# Altium schematic sheet PHE_Keypad_R02.SchDoc
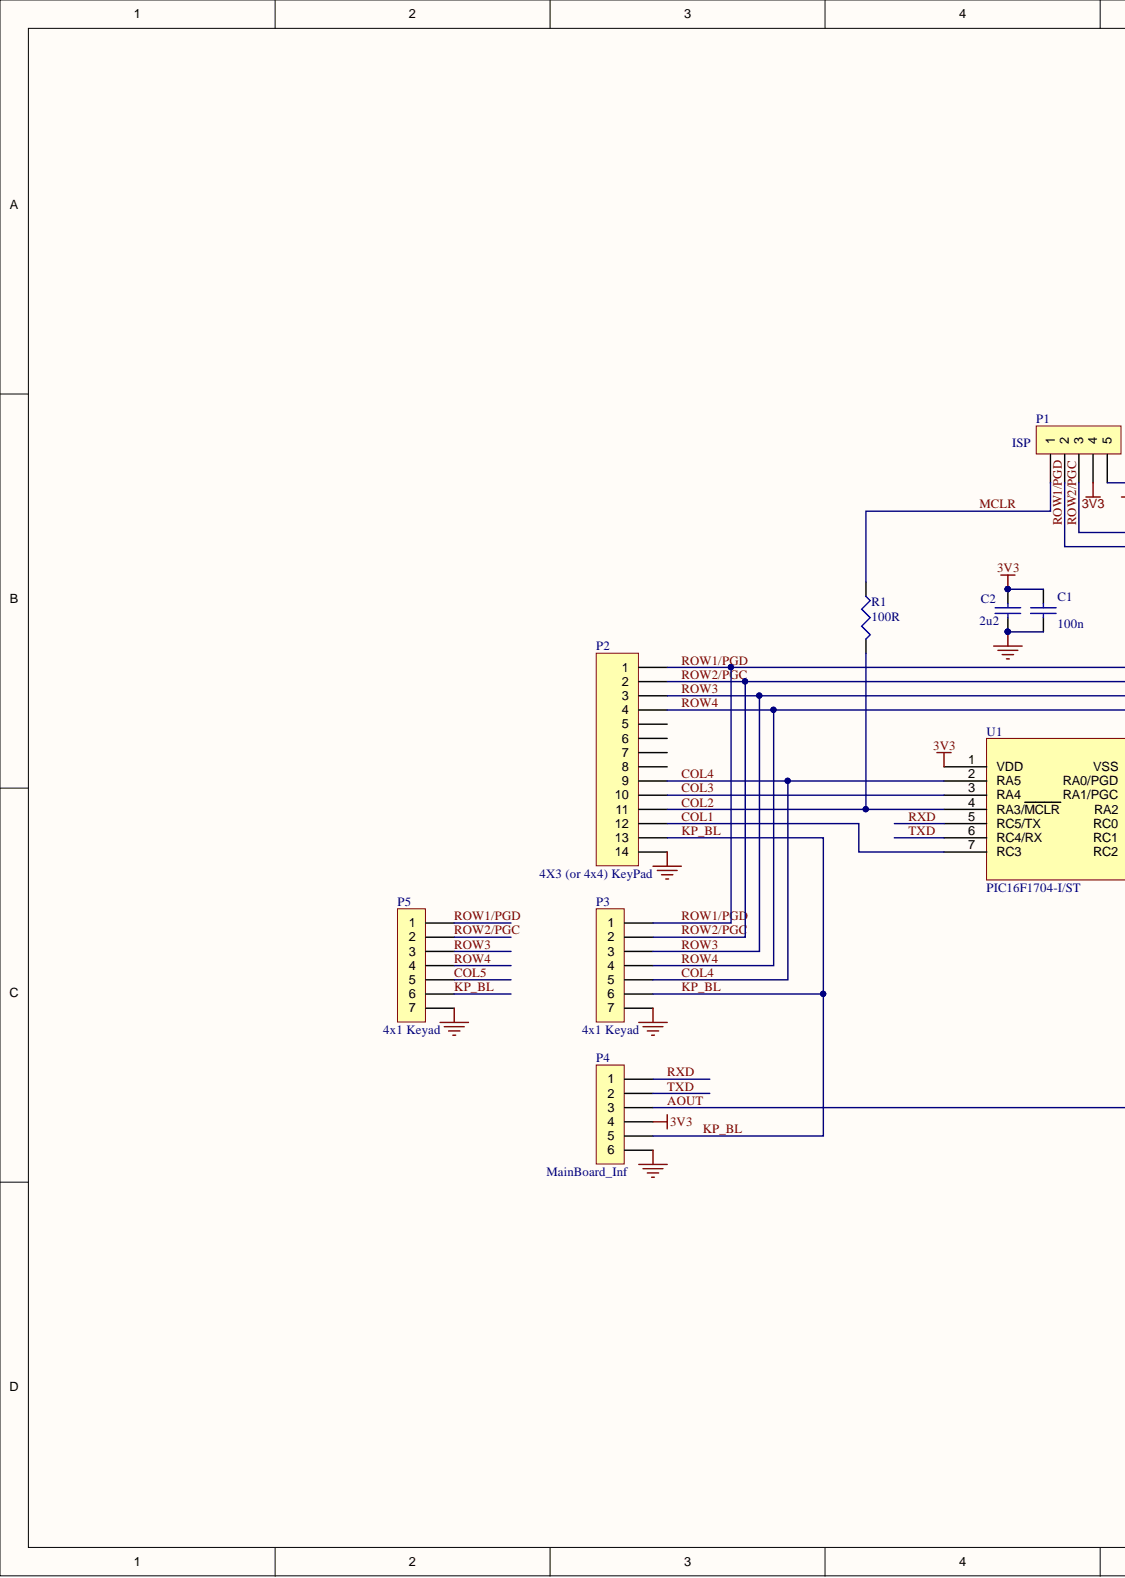
[diagram p0: PHE_Keypad_R02.SchDoc, left]
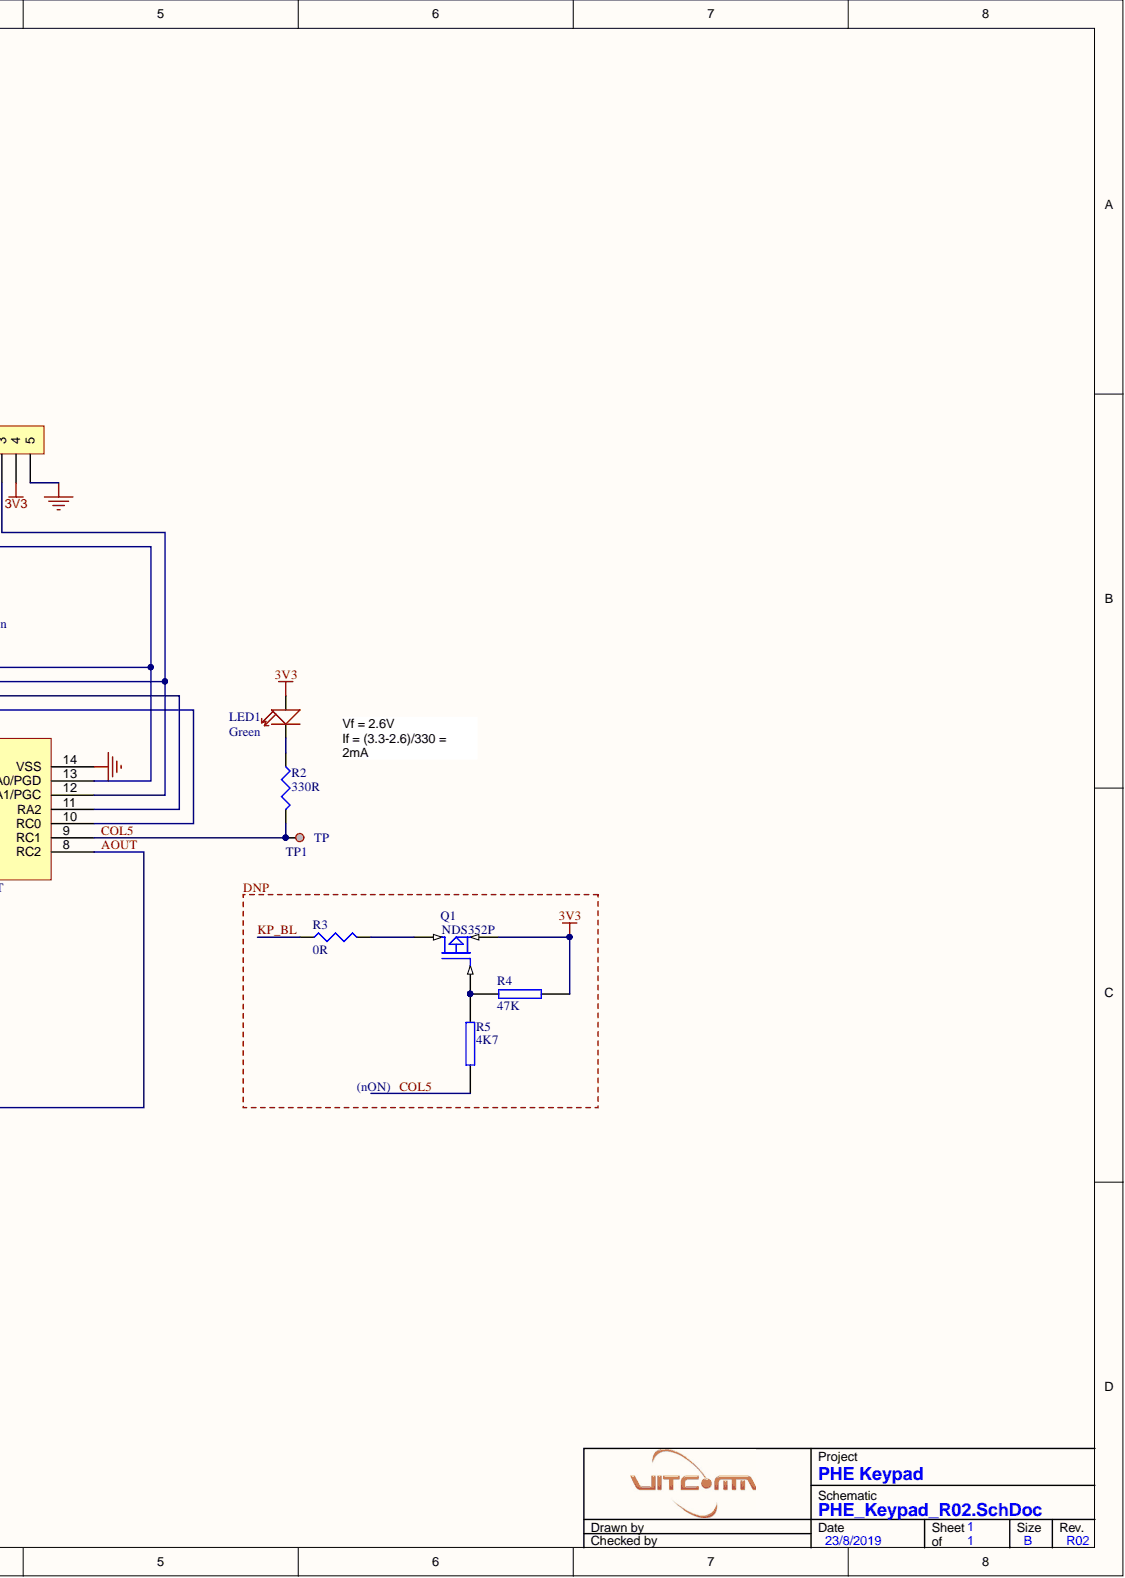
[diagram p1: PHE_Keypad_R02.SchDoc, right]

## Parts
C1  100n  [0603N]
C2  2u2  [0805N]
LED1  Green  [D0603]
P1  ISP  [HDR1X5]
P2  4X3 (or 4x4) KeyPad  [HDR_SMT_1X14_2.54MM_VER]
P3  4x1 Keyad  [HDR1X7]
P4  MainBoard_Inf  [HDR1X6]
P5  4x1 Keyad  [HDR1X7]
Q1  NDS352P  [SOT-23(NDS352P)]
R1  100R  [J1-0603]
R2  330R  [J1-0603]
R3  0R  [J1-0603]
R4  47K  [0603N]
R5  4K7  [0603N]
TP1  TP  [TP_NORM]
U1  PIC16F1704-I/ST  [MCHP-TSSOP-ST14_N]  (value not drawn)

## Nets
3V3: C1.1 C2.1 LED1.+ P1.4 P4.4 Q1.3 R4.1 U1.1(VDD)
GND: C1.2 C2.2 P1.5 P2.14 P3.7 P4.6 P5.7 U1.14(VSS)
AOUT: P4.3 U1.8(RC2)
COL1: P2.12 U1.7(RC3)
COL2: P2.11 R1.2 U1.4(RA3/MCLR)
COL3: P2.10 U1.3(RA4)
COL4: P2.9 P3.5 U1.2(RA5)
COL5: P5.5 R2.2 R5.1 TP1.1 U1.9(RC1)
KP_BL: P2.13 P3.6 P4.5 P5.6 R3.1
MCLR: P1.1 R1.1
ROW1/PGD: P1.2 P2.1 P3.1 P5.1 U1.13(RA0/PGD)
ROW2/PGC: P1.3 P2.2 P3.2 P5.2 U1.12(RA1/PGC)
ROW3: P2.3 P3.3 P5.3 U1.11(RA2)
ROW4: P2.4 P3.4 P5.4 U1.10(RC0)
RXD: P4.1 U1.5(RC5/TX)
TXD: P4.2 U1.6(RC4/RX)
NetLED1_-: LED1.- R2.1
NetQ1_1: Q1.1 R4.2 R5.2
NetQ1_2: Q1.2 R3.2

Not connected: P2 5 6 7 8

## Library data of the parts
C1 C2 = CAP: Capacitor; Component Kind: Standard
LED1 = 007-LED0603: LED, 0603; Component Kind: Standard; Library Name: Seeeduino Stalker v3.0_1.SchLib
P1 = CON5: Connector; Component Kind: Standard
P2 = Header 14: Header, 14-Pin; Component Kind: Standard; LatestRevisionDate: 17-Jul-2002; LatestRevisionNote: Re-released for DXP Platform.; Library Name: Miscellaneous Connectors.IntLib; Signal Integrity: Connector; Publisher: Altium Limited
P3 P5 = CON7: Connector; Component Kind: Standard; Library Name: MYSCH.SchLib
P4 = CON6: Connector; Component Kind: Standard; Library Name: MYSCH.SchLib
Q1 = MOSFET P: Component Kind: Standard
R1-R3 = Res3: Resistor; Value: 1K; Component Kind: Standard; LatestRevisionDate: 29-May-2009; LatestRevisionNote: IPC-7351 Footprint Added.; Library Name: Miscellaneous Devices.IntLib; PackageDescription: Chip Resistor; PackageReference: J1-0603; Published: 8-Jun-2000; Signal Integrity: Res; Simulation: RESISTOR; Publisher: Altium Limited
R4 R5 = RES2: Component Kind: Standard
TP1 = TP: Testpoint; Component Kind: Standard; Library Name: MYSCH.SchLib
U1 = PIC16F1704: PIC16F1704 MCU, 4KW prog, 128B HEF, 512B RAM. CLC, OPA, ZCD, COG, UART, I2C/SPI; Component Kind: Standard; Library Name: MYSCH.SchLib
